# Altium schematic sheet 01_XDRONE.SchDoc(01_XDRONE)
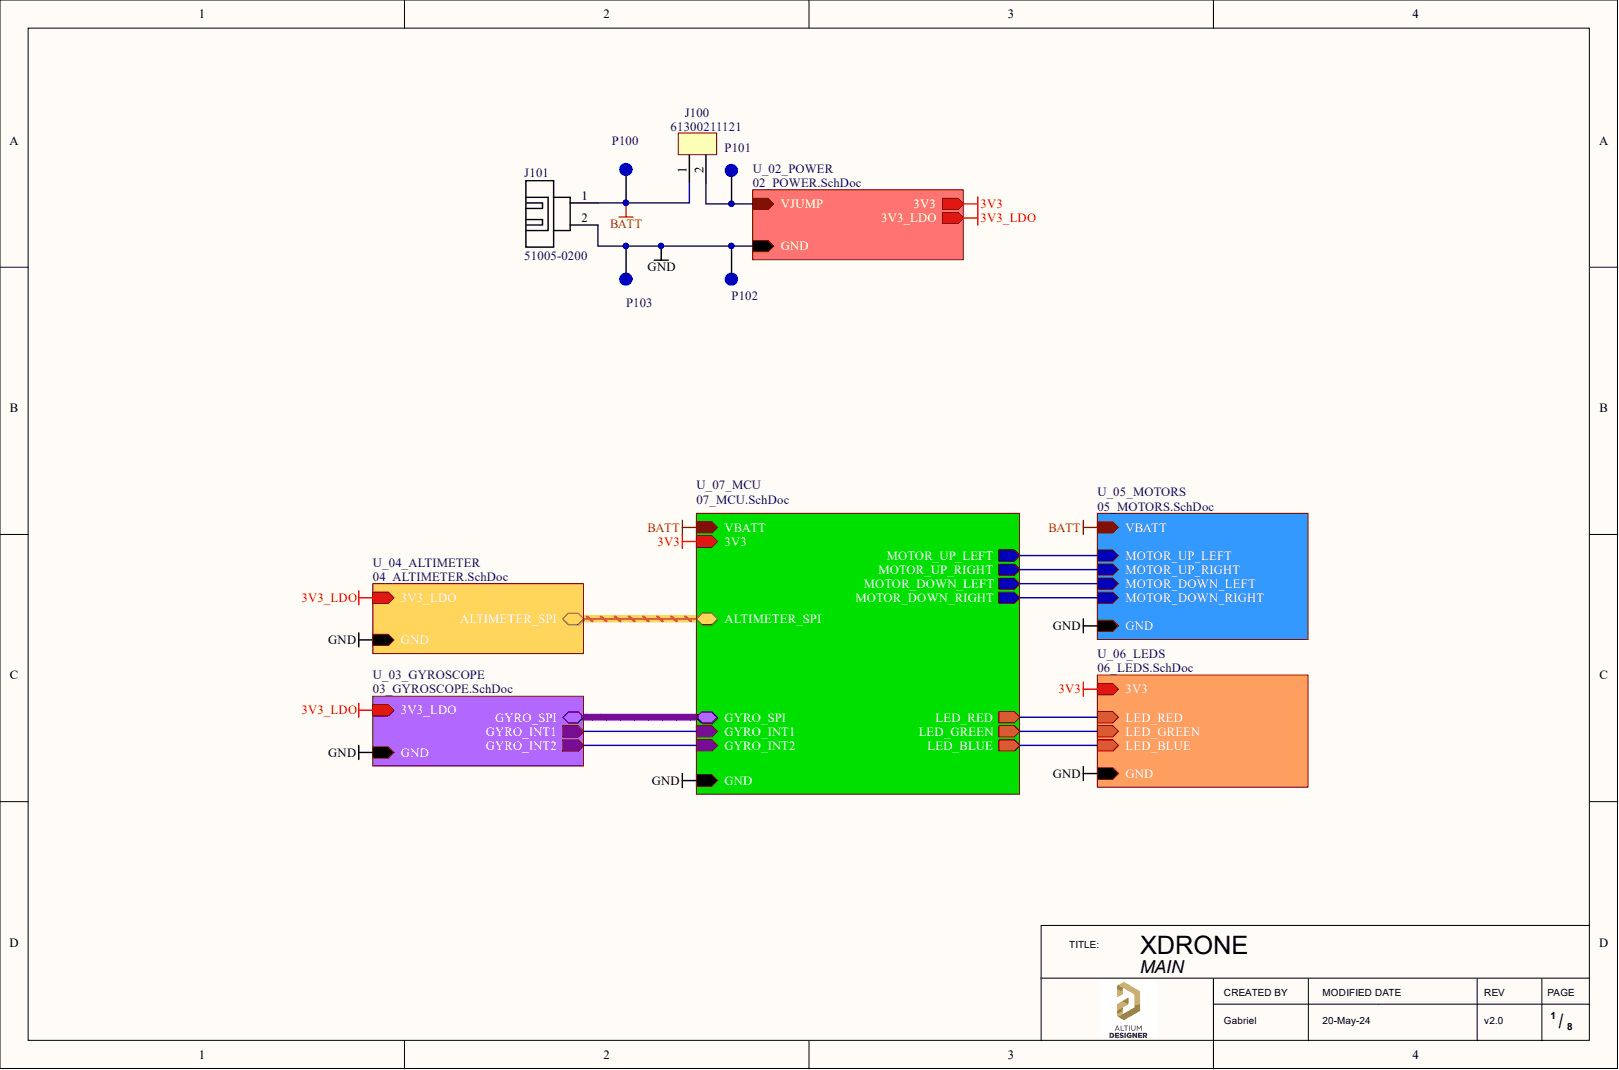
[diagram p0: 01_XDRONE.SchDoc]

## Parts
J100  MANUFACTURER REFERENCE: 61300211121  [CON_2x1PIN_RA_61300211121]  (hidden comment: CON_2x1PIN_ST_61300211121_2.54)
J101  MANUFACTURER REFERENCE: 51005-0200  [CON_2x1PIN_MOLEX_51005-0200]  (hidden comment: CON_2x1PIN_MALE_51005-0200)
P100  TEST_POINT_2mm  [TEST_POINT_2mm]  (value not drawn)
P101  TEST_POINT_2mm  [TEST_POINT_2mm]  (value not drawn)
P102  TEST_POINT_2mm  [TEST_POINT_2mm]  (value not drawn)
P103  TEST_POINT_2mm  [TEST_POINT_2mm]  (value not drawn)

## Nets
BATT: J100.1 J101.1 P100.1
GND: J101.2 P102.1 P103.1
NetJ100_2: J100.2 P101.1
3V3:
3V3_LDO:
ALTIMETER_SPI.ALTIMETER_CLK:
ALTIMETER_SPI.ALTIMETER_CS#:
ALTIMETER_SPI.ALTIMETER_MISO:
ALTIMETER_SPI.ALTIMETER_MOSI:
GYRO_INT1:
GYRO_INT2:
GYRO_SPI.GYRO_CS#:
GYRO_SPI.GYRO_MISO:
GYRO_SPI.GYRO_MOSI:
GYRO_SPI.GYRO_SCK:
LED_BLUE:
LED_GREEN:
LED_RED:
MOTOR_DOWN_LEFT:
MOTOR_DOWN_RIGHT:
MOTOR_UP_LEFT:
MOTOR_UP_RIGHT:

## Library data of the parts
J100 = CON_2x1PIN_ST_61300211121_2.54: CONNECTOR 2x1 PIN ST 61300211121 WE 2.54 PITCH; ANGLE: STRAIGHT; COLUMNS: 2; CURRENT RATING: 3A; GENDER: MALE; PITCH: 2.54 mm; ROWS: 1; Component Kind: Standard; Library Name: Connectors.SchLib; MANUFACTURER: WE; MOUNTING: THT
J101 = CON_2x1PIN_MALE_51005-0200: CONNECTOR 2x1PIN MALE 51005-0200; MANUFACTURER: MOLEX; ANGLE: STRAIGHT; COLUMNS: 1; ROWS: 2; Component Kind: Standard; GENDER: MALE; Library Name: Connectors.SchLib; MOUNTING: SMD
P100-P103 = TEST_POINT_2mm: Component Kind: Standard; Description: TEST_POINT_2mm; Library Name: Connectors.SchLib; MOUNTING: SMD
